# Altium schematic sheet 04_Communication.SchDoc
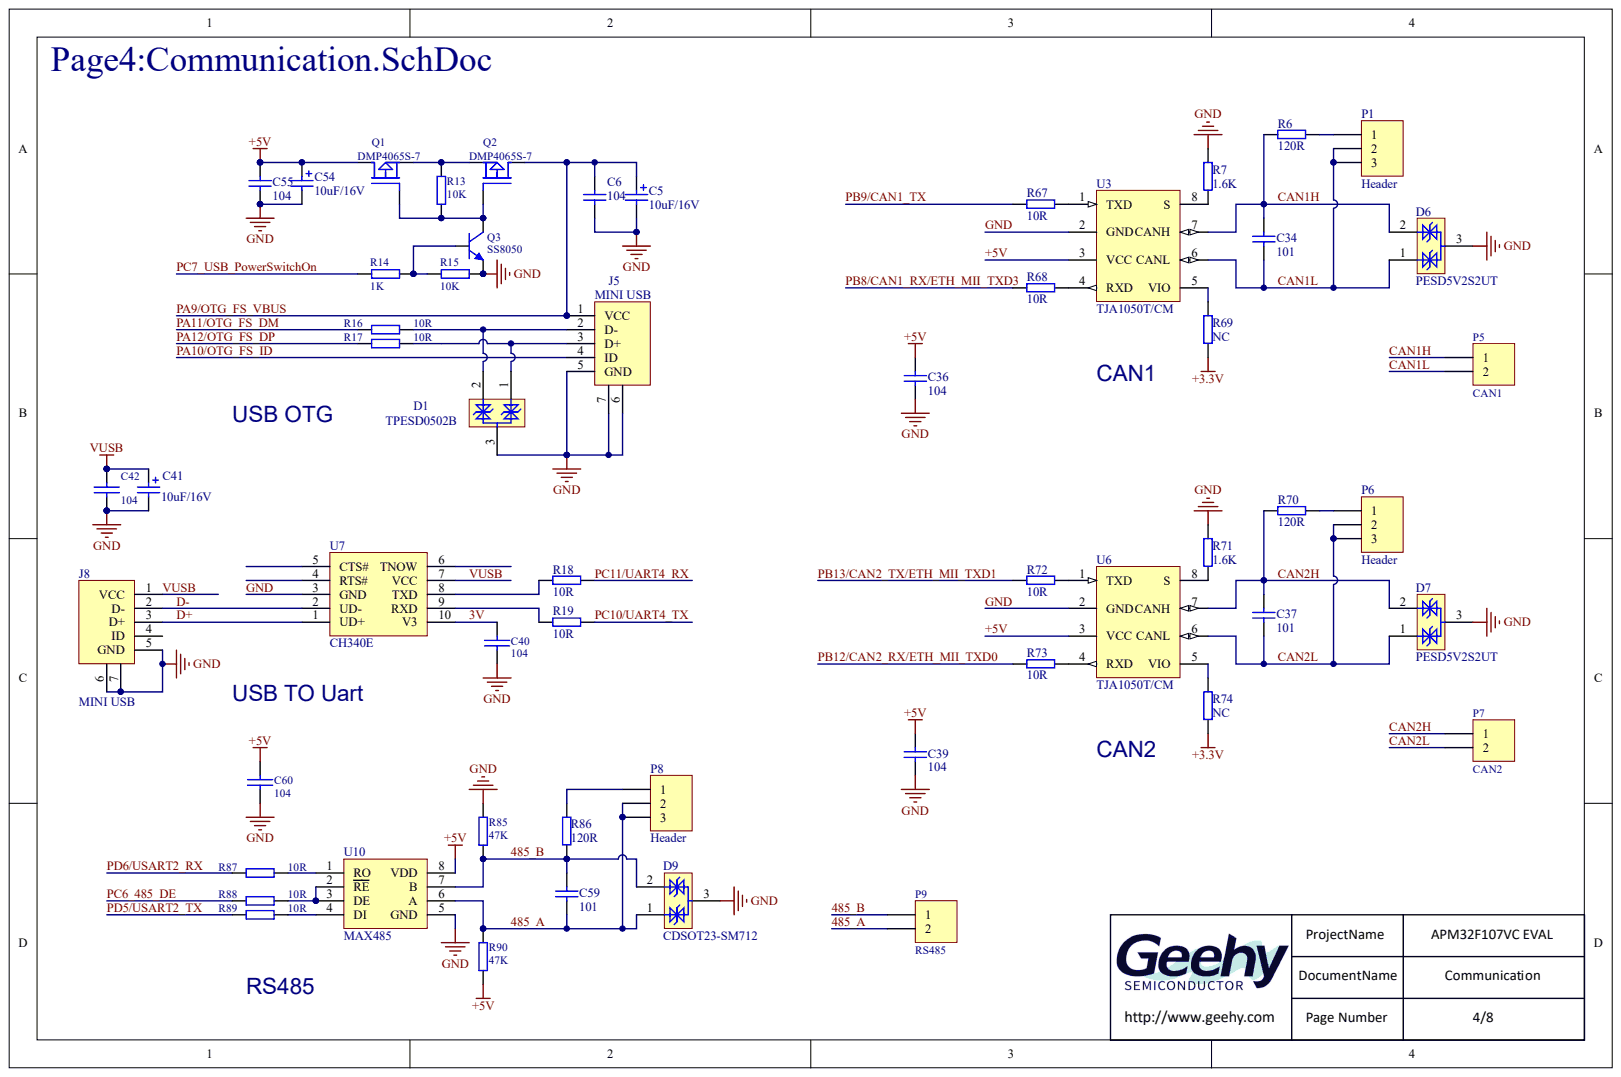
[diagram p0: 04_Communication.SchDoc]

## Parts
C5  10uF/16V
C6  104
C34  101
C36  104
C37  101
C39  104
C40  104
C41  10uF/16V
C42  104
C54  10uF/16V
C55  104
C59  101
C60  104
D1  TPESD0502B
D6  PESD5V2S2UT
D7  PESD5V2S2UT
D9  CDSOT23-SM712
J5  MINI USB
J8  MINI USB
P1  Header
P5  CAN1
P6  Header
P7  CAN2
P8  Header
P9  RS485
Q1  DMP4065S-7
Q2  DMP4065S-7
Q3  SS8050
R6  120R
R7  1.6K
R13  10K
R14  1K
R15  10K
R16  10R
R17  10R
R18  10R
R19  10R
R67  10R
R68  10R
R69  NC
R70  120R
R71  1.6K
R72  10R
R73  10R
R74  NC
R85  47K
R86  120R
R87  10R
R88  10R
R89  10R
R90  47K
U3  TJA1050T/CM
U6  TJA1050T/CM
U7  CH340E
U10  MAX485

## Nets
+3.3V: R69.1 R74.1
3V: C40.2 U7.10(V3)
485_A: C59.1 D9.1 P8.2 P8.3 P9.2 R90.2 U10.6(A)
485_B: C59.2 D9.2 P9.1 R85.1 R86.2 U10.7(B)
+5V: C36.2 C39.2 C54.1 C55.2 C60.2 Q1.2 R90.1 U3.3(VCC) U6.3(VCC) U10.8(VDD)
CAN1H: C34.2 D6.2 P5.1 R6.2 U3.7(CANH)
CAN1L: C34.1 D6.1 P1.2 P1.3 P5.2 U3.6(CANL)
CAN2H: C37.2 D7.2 P7.1 R70.2 U6.7(CANH)
CAN2L: C37.1 D7.1 P6.2 P6.3 P7.2 U6.6(CANL)
D+: J8.3(D+) U7.1(UD+)
D-: J8.2(D-) U7.2(UD-)
GND: C5.2 C6.1 C36.1 C39.1 C40.1 C41.2 C42.1 C54.2 C55.1 C60.1 D1.3 D6.3 D7.3 D9.3 J5.5(GND) J5.6 J5.7 J8.5(GND) J8.6 J8.7 Q3.2 R7.2 R15.1 R71.2 R85.2 U3.2(GND) U6.2(GND) U7.3(GND) U10.5(GND)
PA9/OTG_FS_VBUS: C5.1 C6.2 J5.1(VCC) Q2.2
PA10/OTG_FS_ID: J5.4(ID)
PA11/OTG_FS_DM: R16.1
PA12/OTG_FS_DP: R17.1
PB8/CAN1_RX/ETH_MII_TXD3: R68.2
PB9/CAN1_TX: R67.2
PB12/CAN2_RX/ETH_MII_TXD0: R73.2
PB13/CAN2_TX/ETH_MII_TXD1: R72.2
PC6_485_DE: R88.1
PC7_USB_POWERSWITCHON: R14.2
PC10/UART4_TX: R19.1
PC11/UART4_RX: R18.1
PD5/USART2_TX: R89.1
PD6/USART2_RX: R87.1
VUSB: C41.1 C42.2 J8.1(VCC) U7.7(VCC)
NetD1_1: D1.1 J5.3(D+) R17.2
NetD1_2: D1.2 J5.2(D-) R16.2
NetP1_1: P1.1 R6.1
NetP6_1: P6.1 R70.1
NetP8_1: P8.1 R86.1
NetQ1_1: Q1.1 Q2.1 Q3.3 R13.1
NetQ1_3: Q1.3 Q2.3 R13.2
NetQ3_1: Q3.1 R14.1 R15.2
NetR7_1: R7.1 U3.8(S)
NetR18_2: R18.2 U7.8(TXD)
NetR19_2: R19.2 U7.9(RXD)
NetR67_1: R67.1 U3.1(TXD)
NetR68_1: R68.1 U3.4(RXD)
NetR69_2: R69.2 U3.5(VIO)
NetR71_1: R71.1 U6.8(S)
NetR72_1: R72.1 U6.1(TXD)
NetR73_1: R73.1 U6.4(RXD)
NetR74_2: R74.2 U6.5(VIO)
NetR87_2: R87.2 U10.1(RO)
NetR88_2: R88.2 U10.2(RE) U10.3(DE)
NetR89_2: R89.2 U10.4(DI)

Not connected: J8 4(ID); U7 4(RTS#) 5(CTS#) 6(TNOW)

## Library data of the parts
C5 C41 C54 = (no symbol name): Value: 10uF; Published: 8-Jun-2000; Revision: July-2002: Re-released for DXP Platform.; Publisher: Altium Limited
C6 C36 C39 C55 = (no symbol name): Value: 100pF; Published: 8-Jun-2000; Revision: July-2002: Re-released for DXP Platform.; Publisher: Altium Limited
C34 C37 C40 C42 C59 C60 D1 D6 D7 D9 J5 J8 P1 P5-P9 Q1-Q3 R6 R7 R13-R19 R67-R74 R85-R90 U3 U6 U7 U10 = (no symbol name)
  C34 C37 C59: Published: 8-Jun-2000; LatestRevisionDate: 17-Jul-2002; LatestRevisionNote: Re-released for DXP Platform.; PackageReference: RAD-0.3
  C40 C42 C60: Capy(W): *; Precision(%): *; Voltage(V): *
  P1 P5-P9: LatestRevisionDate: 17-Jul-2002; LatestRevisionNote: Re-released for DXP Platform.
  R6 R7 R18 R19 R67-R74 R86: Published: 8-Jun-2000; LatestRevisionDate: 17-Jul-2002; LatestRevisionNote: Re-released for DXP Platform.; PackageReference: AXIAL-0.4
  C34 C37 C59 P1 P5-P9 R6 R7 R18 R19 R67-R74 R86: Publisher: Altium Limited
